# Altium schematic sheet Microcontroller.SchDoc(Microcontroller)
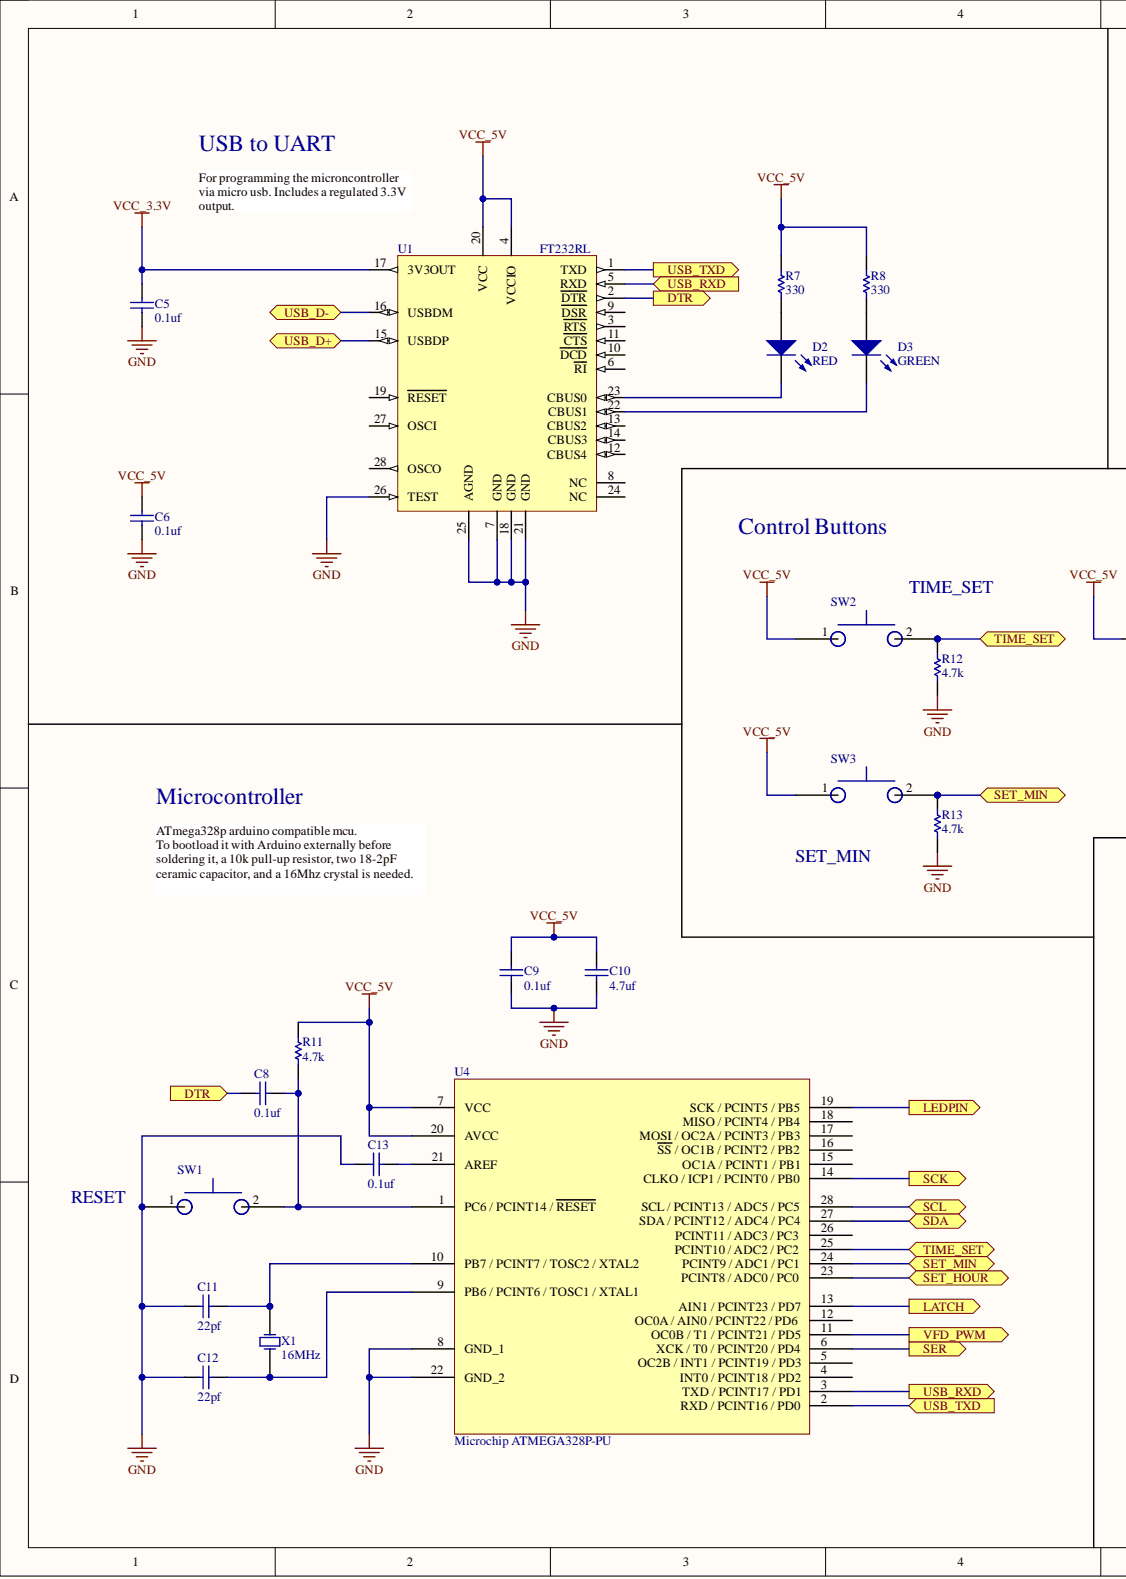
[diagram p0: Microcontroller.SchDoc, left]
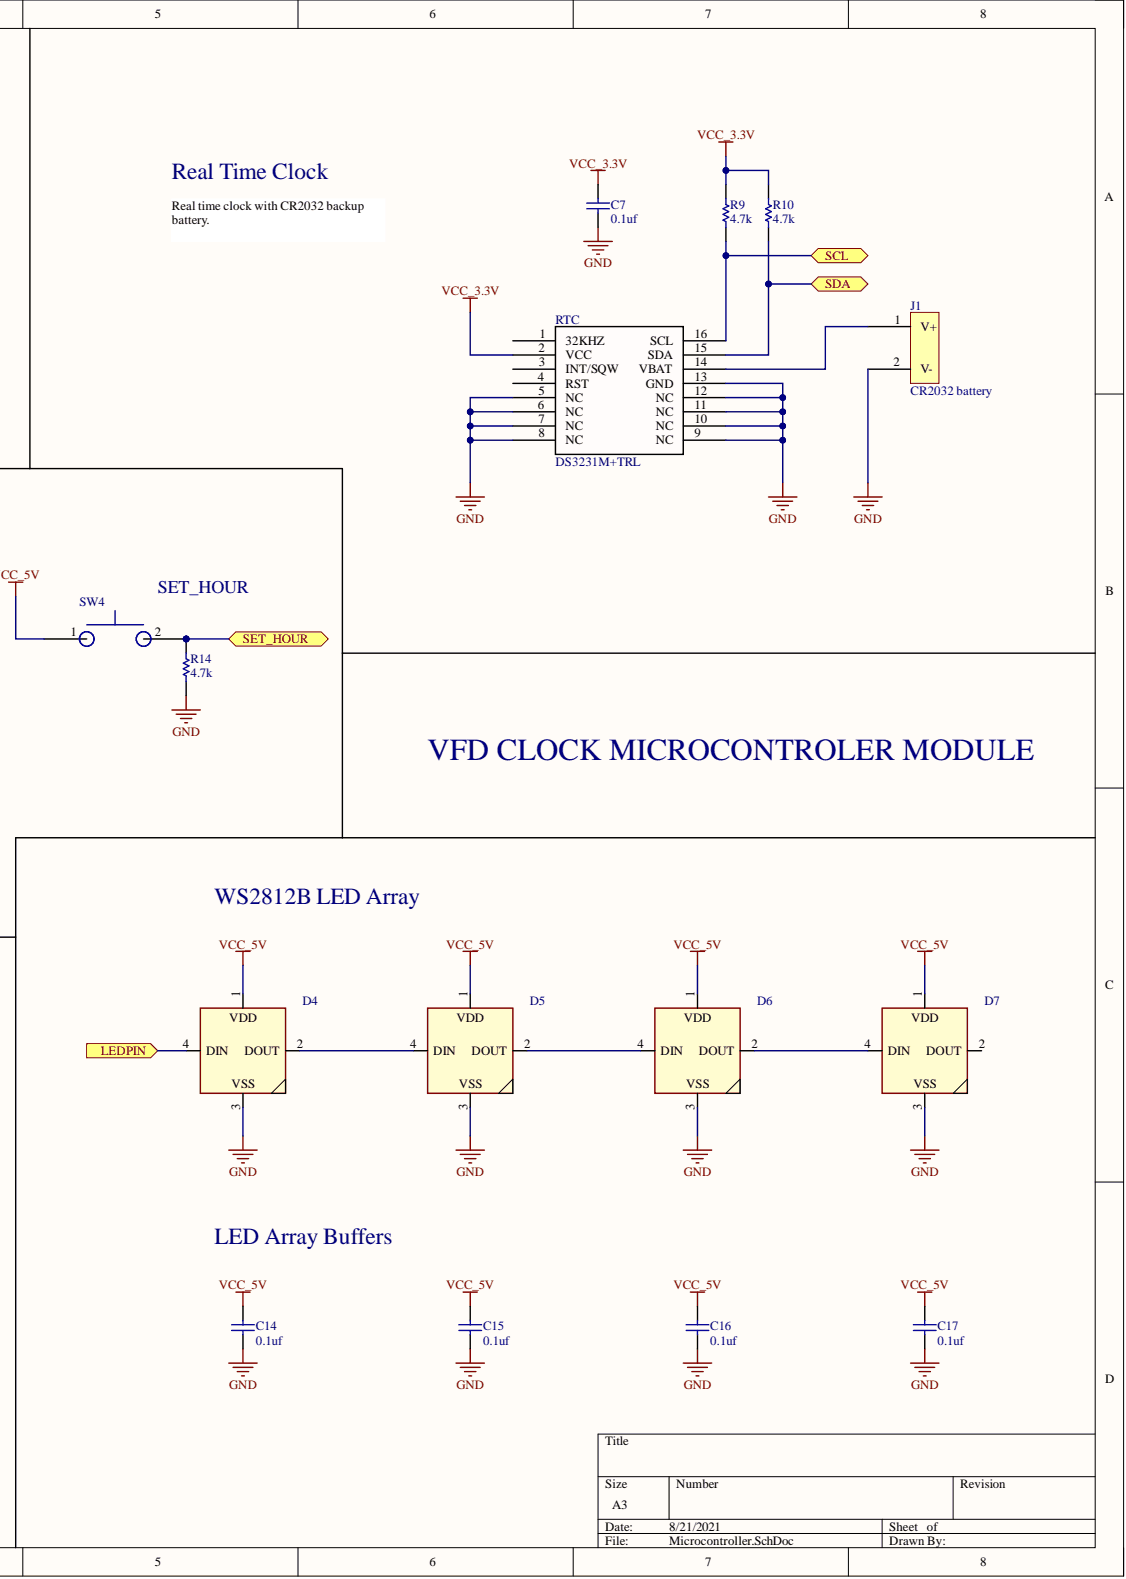
[diagram p1: Microcontroller.SchDoc, right]

## Parts
C5  0.1uf  [PCB-xrh88671zmf2jss4mnlt-1]
C6  0.1uf  [PCB-xrh88671zmf2jss4mnlt-1]
C7  0.1uf  [PCB-xrh88671zmf2jss4mnlt-1]
C8  0.1uf  [PCB-xrh88671zmf2jss4mnlt-1]
C9  0.1uf  [PCB-xrh88671zmf2jss4mnlt-1]
C10  4.7uf  [PCB-xrh88671zmf2jss4mnlt-1]
C11  22pf  [PCB-xrh88671zmf2jss4mnlt-1]
C12  22pf  [PCB-xrh88671zmf2jss4mnlt-1]
C13  0.1uf  [PCB-xrh88671zmf2jss4mnlt-1]
C14  0.1uf  [PCB-xrh88671zmf2jss4mnlt-1]
C15  0.1uf  [PCB-xrh88671zmf2jss4mnlt-1]
C16  0.1uf  [PCB-xrh88671zmf2jss4mnlt-1]
C17  0.1uf  [PCB-xrh88671zmf2jss4mnlt-1]
D2  RED  [PCB-o50kpimdczdlnon84rg0-1]
D3  GREEN  [PCB-o50kpimdczdlnon84rg0-1]
D4  WS2812B RGBLED  [PCB-2nc7kj01rsybilz1z82y-1]  (value not drawn)
D5  WS2812B RGBLED  [PCB-2nc7kj01rsybilz1z82y-1]  (value not drawn)
D6  WS2812B RGBLED  [PCB-2nc7kj01rsybilz1z82y-1]  (value not drawn)
D7  WS2812B RGBLED  [PCB-2nc7kj01rsybilz1z82y-1]  (value not drawn)
J1  CR2032 battery  [PCB-5tuve4cly2pn1hldnbkn-1]
R7  330  [PCB-t328dqyxqxme240c8eho-1]
R8  330  [PCB-t328dqyxqxme240c8eho-1]
R9  4.7k  [PCB-t328dqyxqxme240c8eho-1]
R10  4.7k  [PCB-t328dqyxqxme240c8eho-1]
R11  4.7k  [PCB-t328dqyxqxme240c8eho-1]
R12  4.7k  [PCB-t328dqyxqxme240c8eho-1]
R13  4.7k  [PCB-t328dqyxqxme240c8eho-1]
R14  4.7k  [PCB-t328dqyxqxme240c8eho-1]
RTC  DS3231M+TRL  [PCB-ai0hk62mjio791jjxu7n-1]
SW1  TE Connectivity 2-1825027-0  [PCB-fxxe3lj32jwaqnbiw43b-1]  (value not drawn)
SW2  TE Connectivity 2-1825027-0  [PCB-fxxe3lj32jwaqnbiw43b-1]  (value not drawn)
SW3  TE Connectivity 2-1825027-0  [PCB-fxxe3lj32jwaqnbiw43b-1]  (value not drawn)
SW4  TE Connectivity 2-1825027-0  [PCB-fxxe3lj32jwaqnbiw43b-1]  (value not drawn)
U1  FT232RL  [SSOP-28_M]
U4  Microchip ATMEGA328P-PU  Manufacturer Part Number: ATMEGA328P-PU  [PCB-n0zq5lox7fck5k780cz8-1]
X1  16MHz  [PCB-gy4gor98huej9pvydkys-1]

## Ports
DTR, LATCH, LEDPIN, SCK, SCL, SDA, SER, SET_HOUR, SET_MIN, TIME_SET, USB_D+, USB_D-, USB_RXD, USB_TXD, VFD_PWM

## Nets
GND: C5.1 C6.1 C7.1 C9.1 C10.1 C11.2 C12.2 C13.2 C14.2 C15.2 C16.2 C17.2 D4.3 D5.3 D6.3 D7.3 J1.2 R12.2 R13.2 R14.2 RTC.5(NC) RTC.6(NC) RTC.7(NC) RTC.8(NC) RTC.9(NC) RTC.10(NC) RTC.11(NC) RTC.12(NC) RTC.13(GND) SW1.1 U1.7(GND) U1.18(GND) U1.21(GND) U1.25(AGND) U1.26(TEST) U4.8(GND_1) U4.22(GND_2)
VCC_3.3V: C5.2 C7.2 R9.2 R10.2 RTC.2(VCC) U1.17(3V3OUT)
VCC_5V: C6.2 C9.2 C10.2 C14.1 C15.1 C16.1 C17.1 D4.1 D5.1 D6.1 D7.1 R7.2 R8.2 R11.1 SW2.1 SW3.1 SW4.1 U1.4(VCCIO) U1.20(VCC) U4.7(VCC) U4.20(AVCC)
DTR: C8.2 U1.2(DTR) ; port DTR
LATCH: U4.13(AIN1_/_PCINT23_/_PD7) ; port LATCH
LEDPIN: D4.4(DIN) U4.19(SCK_/_PCINT5_/_PB5) ; port LEDPIN
SCK: U4.14(CLKO_/_ICP1_/_PCINT0_/_PB0) ; port SCK
SCL: R9.1 RTC.16(SCL) U4.28(SCL_/_PCINT13_/_ADC5_/_PC5) ; port SCL
SDA: R10.1 RTC.15(SDA) U4.27(SDA_/_PCINT12_/_ADC4_/_PC4) ; port SDA
SER: U4.6(XCK_/_T0_/_PCINT20_/_PD4) ; port SER
SET_HOUR: R14.1 SW4.2 U4.23(PCINT8_/_ADC0_/_PC0) ; port SET_HOUR
SET_MIN: R13.1 SW3.2 U4.24(PCINT9_/_ADC1_/_PC1) ; port SET_MIN
TIME_SET: R12.1 SW2.2 U4.25(PCINT10_/_ADC2_/_PC2) ; port TIME_SET
USB_RXD: U1.5(RXD) U4.3(TXD_/_PCINT17_/_PD1) ; port USB_RXD
USB_TXD: U1.1(TXD) U4.2(RXD_/_PCINT16_/_PD0) ; port USB_TXD
VFD_PWM: U4.11(OC0B_/_T1_/_PCINT21_/_PD5) ; port VFD_PWM
NetC8_1: C8.1 R11.2 SW1.2 U4.1(PC6_/_PCINT14_/_RESET)
NetC11_1: C11.1 U4.10(PB7_/_PCINT7_/_TOSC2_/_XTAL2) X1.2
NetC12_1: C12.1 U4.9(PB6_/_PCINT6_/_TOSC1_/_XTAL1) X1.1
NetC13_1: C13.1 U4.21(AREF)
NetD2_1: D2.1 R7.1
NetD2_2: D2.2 U1.23(CBUS0)
NetD3_1: D3.1 R8.1
NetD3_2: D3.2 U1.22(CBUS1)
NetD4_2: D4.2(DOUT) D5.4(DIN)
NetD5_2: D5.2(DOUT) D6.4(DIN)
NetD6_2: D6.2(DOUT) D7.4(DIN)
NetJ1_1: J1.1 RTC.14(VBAT)
NetU1_15: U1.15(USBDP)
NetU1_16: U1.16(USBDM)

Not connected: D7 2(DOUT); RTC 1(32KHZ) 3(INT/SQW) 4(RST); U1 3(RTS) 6(RI) 8(NC) 9(DSR) 10(DCD) 11(CTS) 12(CBUS4) 13(CBUS2) 14(CBUS3) 19(RESET) 24(NC) 27(OSCI) 28(OSCO); U4 4(INT0_/_PCINT18_/_PD2) 5(OC2B_/_INT1_/_PCINT19_/_PD3) 12(OC0A_/_AIN0_/_PCINT22_/_PD6) 15(OC1A_/_PCINT1_/_PB1) 16(SS_/_OC1B_/_PCINT2_/_PB2) 17(MOSI_/_OC2A_/_PCINT3_/_PB3) 18(MISO_/_PCINT4_/_PB4) 26(PCINT11_/_ADC3_/_PC3)

## Library data of the parts
C5-C17 = CMP-7104bbe86e53aec3-2: Capacitor [Würth Elektronik] 885012207051 Capacitor; Capacitance: 1.00 µF; Capacitance Tolerance: ±10%; Dielectric Characteristic: X7R; Voltage Rating (DC): 16.0 V; Component Kind: Standard; Library Name: My Personal Space; Manufacturer Part Number: 885012207051; Octopart URL: https://octopart.com/885012207051-w%C3%BCrth+elektronik-65419825; OctopartID: 7104bbe86e53aec3; Packaging: Cut Tape (CT); RoHS: Compliant
D2 D3 = CMP-81a74a7f92ca5e60-3: LS R976-NR-1; Component Kind: Standard; Library Name: My Personal Space
D4-D7 = CMP-57009d1bdf0888c7-30: Worldsemi WS2812b 5050 RGB LED with IC(same as SK6812); Component Kind: Standard; Library Name: My Personal Space
J1 = CMP-20b6e82fb80aff71-5: Battery Retainer Coin, 20.0mm 1 Cell SMD (SMT) Tab; Manufacturer: Keystone Electronics; Manufacturer Part Number: 3034TR; Vendor Part Number: 36-3034CT-ND; Battery Series: 2020, 2025, 2032; Battery Type, Function: Coin Cell, Retainer; Number of Cells: 1; Vendor: Digikey; Battery Cell Size: Coin, 20.0mm; Component Kind: Standard; Cost: 0.44; Height Above Board: 0.160" (4.06mm); HelpURL: http://www.keyelco.com/product-pdf.cfm?p=3904; Library Name: My Personal Space; Mounting Type: PCB, Surface Mount; Octopart URL: https://octopart.com/3034tr-keystone-26459610; OctopartID: 20b6e82fb80aff71; Style: Retainer; Termination Style: SMD (SMT) Tab; Vendor URL: https://www.digikey.com/product-detail/en/keystone-electronics/3034TR/36-3034CT-ND/4833649
R7-R14 = CMP-1853782-3: RMCF Series 0805 0.125 W 1 kOhm ±5 % ±100 ppm/°C SMT Thick Film Chip Resistor; Manufacturer Part Number: RMCF0805JT1K00; Lead-Free Status: Lead Free; Power Rating: 125 mW; Resistance: 1.00 kΩ; Resistance Tolerance: ±5%; Case/Package: 0805; Component Kind: Standard; Library Name: My Personal Space; Mounting Style: Surface Mount; Octopart URL: http://octopart.com/rmcf0805jt1k00-stackpole+electronics-19214505; OctopartID: 2352eb7d369dfc95; Packaging: Tape & Reel (TR); RoHS: Compliant
RTC = CMP-1022291-4: Real Time Clock Serial 16-Pin SOIC W T/R; Lead-Free Status: Lead Free; Supply Voltage (DC): 5.50 V (max), 2.30 V (min); Component Kind: Standard; Library Name: My Personal Space; Lifecycle Status: Active; Manufacturer Part Number: DS3231M+TRL; Number of Pins: 16; Octopart URL: https://octopart.com/ds3231m%2Btrl-maxim+integrated-44322454; OctopartID: 3fd3f47d5423e014; Operating Temperature: -40.0 °C (min); Packaging: Cut Tape (CT); RoHS: Compliant
SW1-SW4 = CMP-84634feca20b5ba4-1: Switch Tactile OFF (ON) SPST Round Button PC Pins 0.05A 24VDC 1.57N Thru-Hole Loose Piece; ELV Compliant: Compliant; Lead-Free Status: Lead Free; Component Kind: Standard; Contact Material: Copper Alloy; Contact Plating: Silver; Library Name: My Personal Space; Lifecycle Status: Active; Manufacturer Part Number: 2-1825027-0; Mounting Style: Through Hole; Octopart URL: http://octopart.com/2-1825027-0-te+connectivity-42271502; OctopartID: 84634feca20b5ba4; Packaging: Tape & Reel (TR); RoHS: Compliant
U1 = CMP-2901179-4: USB UART Asynchronous Serial Data Transfer Chip, 28-pin SSOP, Tube; Manufacturer Part Number: FT232RL-TUBE; Component Kind: Standard; Library Name: My Personal Space; Mounting Style: Surface Mount; Octopart Url: https://octopart.com/ft232rl-tube-ftdi-22593926; OctopartID: 45e58db7a1059a22; RoHS: Compliant
U4 = CMP-973188-63: ATMEGA328P-PU; 8 bit Microcontroller AVR 20MHz 1; 32kb Flash; 2kb RAM; I2C SPI 2; Manufacturer: Atmel; Clock Speed: 20.0 MHz; Lead-Free Status: Lead Free; RAM Memory Size: 2048 B; Supply Voltage (DC): 1.80 V (min); Case/Package: SPDIP; Component Kind: Standard; Library Name: My Personal Space; Lifecycle Status: Not Listed by Manufacturer; Mounting Style: Through Hole; Number of Pins: 28; Octopart URL: https://octopart.com/atmega328p-pu-microchip-77760224; OctopartID: 60cead66c348bf09; Operating Temperature: -40.0 °C (min); REACH SVHC Compliance: No SVHC; RoHS: Compliant
X1 = CMP-2b32e236d469f4f5-1: Raltron Electronics AS-16.000-18  Crystal 16MHz; +/-50ppm; 2-Pin HC-49-S; Equivalent Series Resistance (ESR): 40.0 Ω; Frequency: 16.0 MHz; Load Capacitance: 18.0 pF; Component Kind: Standard; Library Name: My Personal Space; Manufacturer Part Number: AS-16.000-18; Mounting Style: Through Hole; Number of Pins: 2; Octopart URL: https://octopart.com/as-16.000-18-raltron+electronics-973373; OctopartID: 2b32e236d469f4f5; Operating Temperature: -20.0 °C to 70.0 °C; REACH SVHC Compliance: No SVHC; RoHS: Compliant
